# Altium schematic sheet Power.SchDoc(U_Power)
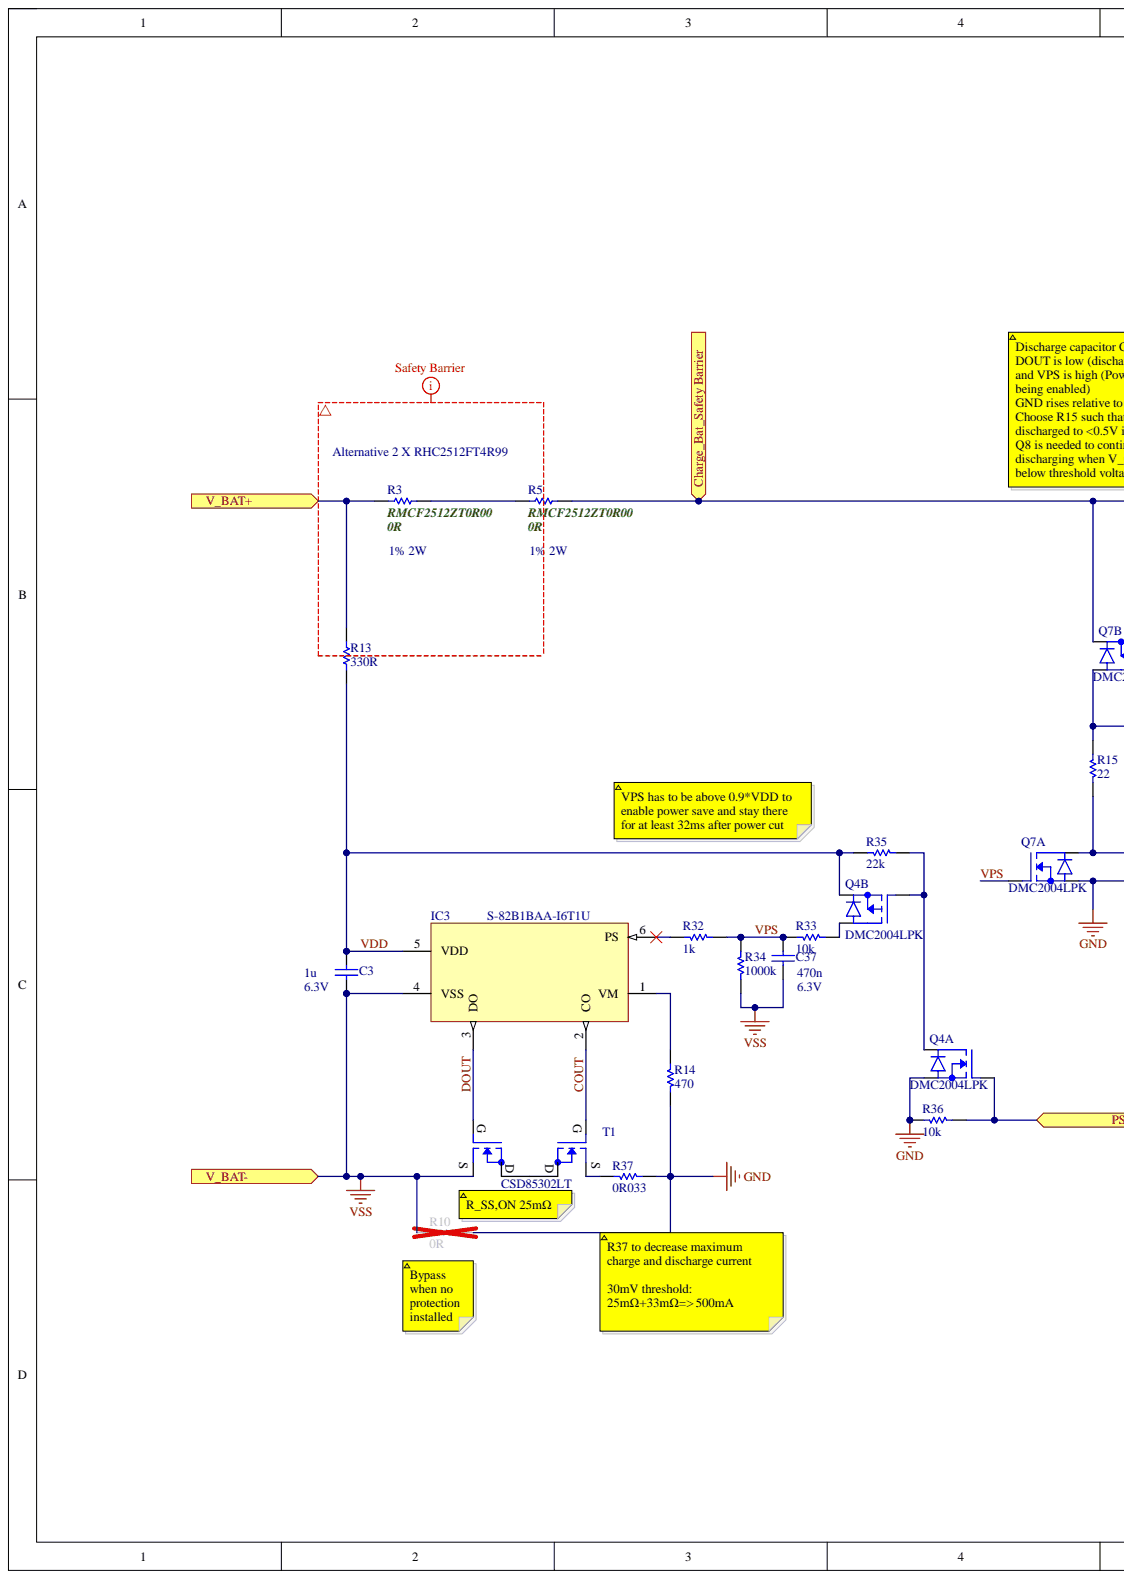
[diagram p0: Power.SchDoc, left]
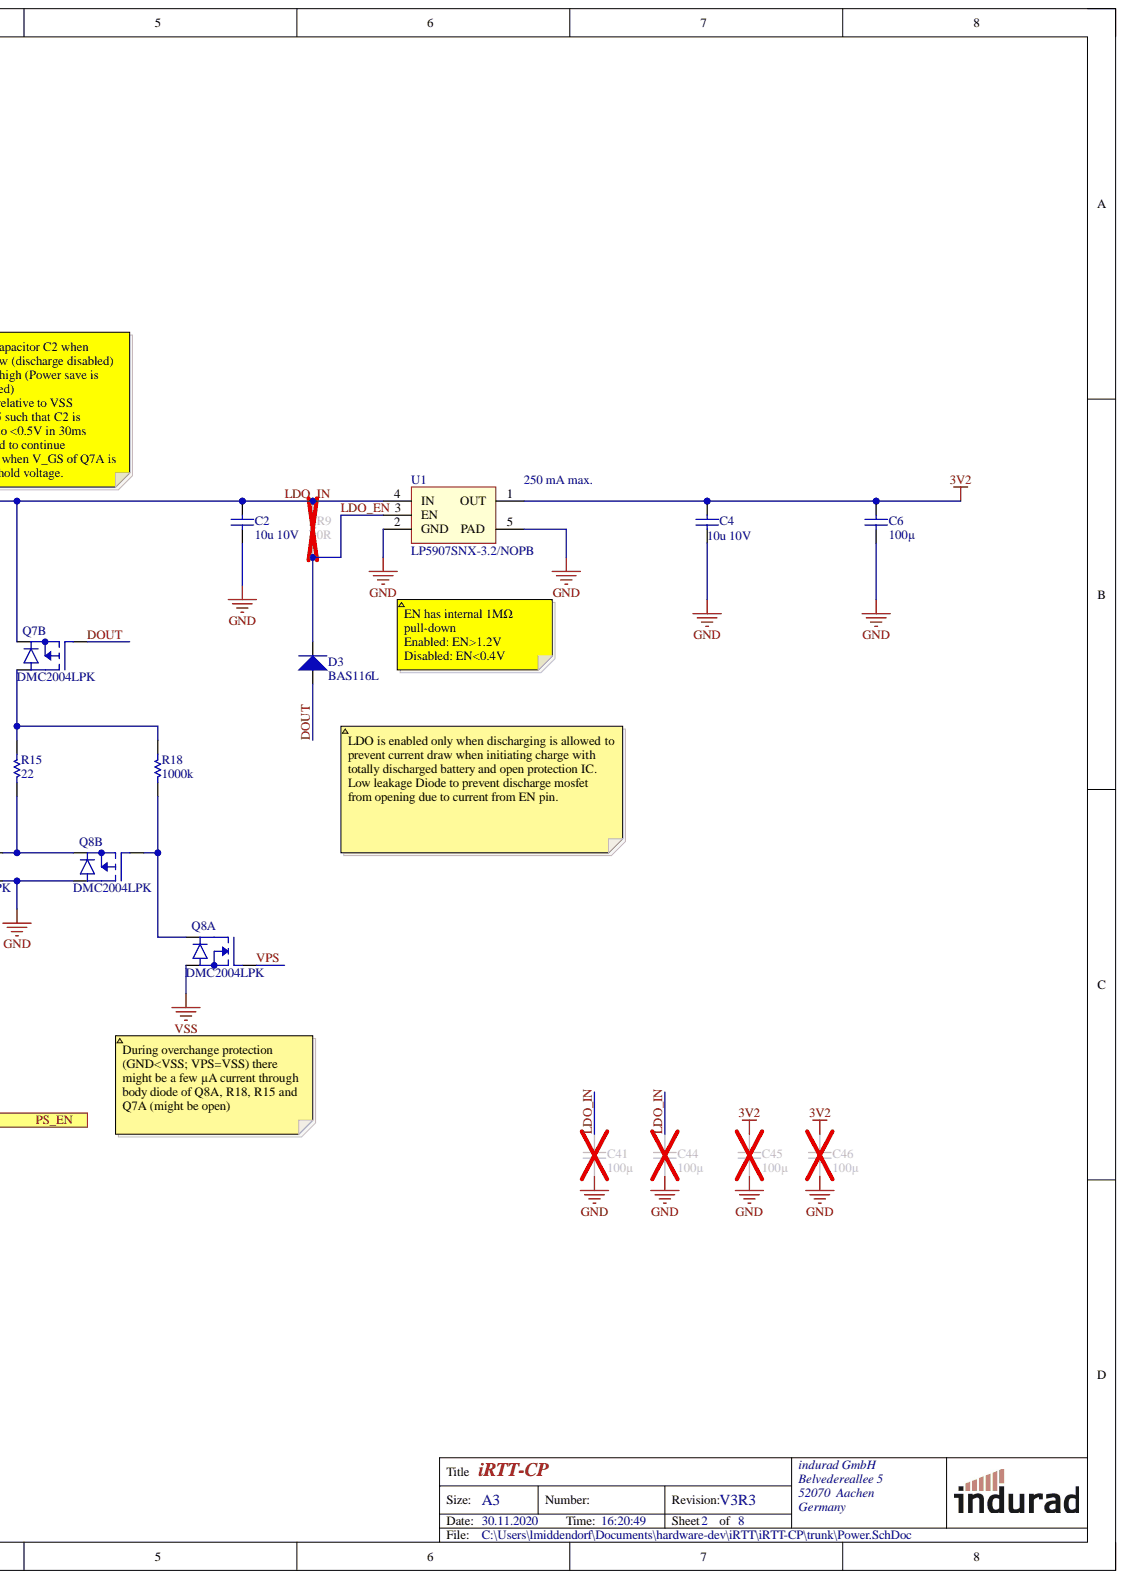
[diagram p1: Power.SchDoc, right]

## Parts
C2  10u 10V  [0805_N_(2012)]
C3  value 1u  Voltage: 6.3V  [0402_N_(1005)]  (hidden comment: 1u, 6.3V, X7R)
C4  10u 10V  value 10u  [0805_N_(2012)]
C6  100µ  [1206_N_(3216)]
C37  value 470n  Voltage: 6.3V  [0402_N_(1005)]  (hidden comment: 470n, 6.3V, X7R)
C41  100µ  [1210_N_(3225)]
C44  100µ  [1210_N_(3225)]
C45  100µ  [1210_N_(3225)]
C46  100µ  [1210_N_(3225)]
D3  BAS116L  [DFN1006-2]
IC3  S-82B1BAA-I6T1U  [SNT-6A]
Q4  DMC2004LPK  [X1-DFN1612-6]  (parts A,B)
Q7  DMC2004LPK  [X1-DFN1612-6]  (parts A,B)
Q8  DMC2004LPK  [X1-DFN1612-6]  (parts A,B)
R3  RMCF2512ZT0R00  value 0R  [CAPC_2512_RHC]
R5  RMCF2512ZT0R00  value 0R  [CAPC_2512_RHC]
R9  0R  [0201_N_(0603)]
R10  0R  [0402_N_(1005)]
R13  330R  [0603_N_(1608)]
R14  470  [0201_N_(0603)]
R15  22  [0603_N_(1608)]
R18  1000k  [0201_N_(0603)]
R32  1k  [0201_N_(0603)]
R33  10k  [0201_N_(0603)]
R34  1000k  [0201_N_(0603)]
R35  22k  [0201_N_(0603)]
R36  10k  [0201_N_(0603)]
R37  0R033  [0603_N_(1608)]
T1  Manufacturer Part Number: CSD85302LT  [4-XFLGA]  (hidden comment: N-MOSFET)
U1  LP5907SNX-3.2/NOPB  [4-XDFN]

## Ports
Charge_Bat_Safety Barrier, PS_EN, V_BAT+, V_BAT-

## Nets
3V2: C4.2 C6.2 C45.2 C46.2 U1.1(OUT)
GND: C2.1 C4.1 C6.1 C41.1 C44.1 C45.1 C46.1 Q4.1 Q7.1 Q8.3 R10.2 R14.1 R36.1 R37.1 U1.2(GND) U1.5(PAD)
VSS: C3.1 C37.1 IC3.4(VSS) Q8.1 R10.1 R34.2 T1.2
COUT: IC3.2(CO) T1.1
DOUT: D3.2 IC3.3(DO) Q7.5 T1.3
LDO_EN: D3.1 R9.2 U1.3(EN)
LDO_IN: C2.2 C41.2 C44.2 Q7.4 R5.2 R9.1 U1.4(IN) ; port Charge_Bat_Safety Barrier
PS_EN: Q4.2 R36.2 ; port PS_EN
V_BAT+: R3.1 R13.2 ; port V_BAT+
VDD: C3.2 IC3.5(VDD) Q4.4 R13.1 R35.2
VPS: C37.2 Q7.2 Q8.2 R32.1 R33.2 R34.1
NetIC3_1: IC3.1(VM) R14.2
NetIC3_6: IC3.6(PS) R32.2
NetQ4_3: Q4.3 R33.1
NetQ4_5: Q4.5 Q4.6 R35.1
NetQ7_3: Q7.3 R15.1 R18.1
NetQ7_6: Q7.6 Q8.4 R15.2
NetQ8_5: Q8.5 Q8.6 R18.2
NetR3_2: R3.2 R5.1
NetR37_2: R37.2 T1.4

## Library data of the parts
C2-C4 C37 C41 C44-C46 = Cap: Capacitor; Component Kind: Standard; Signal Integrity: Cap; Simulation: CAP; Library Name: Indurad.SchLib
  C2: Value: 10u 10V
  C3 C37: Dielectric: X7R
  C4: Supplier 1: Farnell; Supplier Part Number 1: 2118132
  C41 C44-C46: Value: 100µ
C6 = Cap: Capacitor; Manufacturer: Murata Electronics North America; Manufacturer Part Number: GRM31CR60J107ME39L; Capacitance: 100µF; Temperature Coefficient: X5R; Tolerance: ±20%; Voltage - Rated: 6.3V; Applications: General Purpose; Category: Capacitors; Component Kind: Standard; ComponentLink1Description: http://www.murata.com/~/media/webrenewal/support/library/catalog/products/capacitor/mlcc/c02e.ashx; ComponentLink1URL: http://www.murata.com/~/media/webrenewal/support/library/catalog/products/capacitor/mlcc/c02e.ashx; Features: -; Height - Seated (Max): -; Lead Spacing: -; Lead Style: -; Mounting Type: Surface Mount, MLCC; Operating Temperature: -55°C ~ 85°C; Package / Case: 1206 (3216 Metric); Packaging: Tape & Reel (TR); Pricing 1: 2000=0.30483, 4000=0.2845, 10000=0.27434, 14000=0.27028, 50000=0.2591, 100000=0.24894 (EUR); Ratings: -; RoHS: RoHS Compliant; Signal Integrity: Cap; Simulation: CAP; Size / Dimension: 0.126" L x 0.063" W (3.20mm x 1.60mm); Stock 1: 132000; Supplier 1: Digi-Key; Supplier Part Number 1: 490-4539-2-ND; Thickness (Max): 0.071" (1.80mm); Value: 100µ; Library Name: Indurad.SchLib
D3 = BAS116L: Low-leakage diode; Manufacturer: Diodes; Manufacturer Part Number: BAS116LPH4-7B; Average Rectified Current: 215mA; Element Configuration: Single; Forward Voltage: 1.25V; Max Power Dissipation: 300mW; Max Repetitive Reverse Voltage (Vrrm): 85V; Max Surge Current: 4A; Peak Reverse Current: 80nA; Power Rating: 200mW; Recovery Time: 3000ns; Reverse Recovery Time: 3us; Case/Package: DFN; Component Kind: Standard; ComponentLink8Description: Data Sheet; ComponentLink8URL: https://www.diodes.com/assets/Datasheets/BAS116LPH4.pdf; Contact Plating: Gold; Forward Current: 215mA; Height: 1.075mm; Lead Free: Lead Free; Length: 1.08mm; Library Name: D_Diode.SchLib; Max Operating Temperature: 150°C; Max Reverse Voltage (DC): 85V; Min Operating Temperature: -55°C; Mount: Surface Mount; Number of Pins: 2; Package Quantity: 10000; Packaging: Tape and Reel; Peak Non-Repetitive Surge Current: 4A; Power Dissipation: 300mW; REACH SVHC: No SVHC; RoHS: Yes; Supplier 1: Digi-Key; Supplier 2: Farnell; Supplier 3: Mouser; Supplier Part Number 1: BAS116LPH4-7BDICT-ND; Supplier Part Number 2: 3127201; Supplier Part Number 3: 621-BAS116LPH4-7B; Width: 0.675mm
IC3 = S-82B1BAA: BATTERY PROTECTION IC WITH POWER-SAVING FUNCTION FOR 1-CELL PACK; Manufacturer: ABLIC; Component Kind: Standard; ComponentLink1Description: Data Sheet; ComponentLink1URL: https://www.ablic.com/en/doc/datasheet/battery_protection/S82B1B_E.pdf; Library Name: IC_Integrated_Circuit.SchLib; Manufacturer Part Number: S-82B1BAA-I6T1U; Supplier 1: DigiKey; Supplier Part Number 1: 1662-S-82B1BAA-I6T1UCT-ND
Q4 Q7 Q8 = FET_DMC2004LPK: COMPLEMENTARY PAIR ENHANCEMENT MODE MOSFET; Manufacturer: Diodes; Manufacturer Part Number: DMC2004LPK-7; Continuous Drain Current (ID): 600mA; Drain to Source Breakdown Voltage: 20V; Drain to Source Resistance: 400mR; FET Type: N and P-Channel; Gate to Source Voltage (Vgs): 8V; Input Capacitance: 150pF; Max Power Dissipation: 500mW; Number of Channels: 2; Rds On Max: 550mR; Case/Package: DFN; Component Kind: Standard; ComponentLink1Description: Data Sheet; ComponentLink1URL: https://www.diodes.com/assets/Datasheets/ds30854.pdf; Drain to Source Voltage (Vdss): 20V; Height: 0.48mm; Length: 1.6mm; Library Name: Q_Transistor.SchLib; Max Operating Temperature: 150°C; Min Operating Temperature: -65°C; Mount: Surface Mount; Number of Elements: 2; Number of Pins: 6; Package Quantity: 3000; Packaging: Tape and Reel; Power Dissipation: 500mW; Pricing 1: 1=0,41000, 10=0,35100, 100=0,24340, 500=0,19000, 1000=0,15444 (USD); Pricing 2: 1=0,41000, 10=0,34200, 100=0,20800, 1000=0,16100, 3000=0,13800, 9000=0,13800 (USD); Radiation Hardening: No; REACH SVHC: No SVHC; RoHS: Yes; Stock 1: 5425; Stock 2: 2996; Supplier 1: Digi-Key; Supplier 2: Mouser; Supplier Part Number 1: DMC2004LPK-7DICT-ND; Supplier Part Number 2: 621-DMC2004LPK-7; Weight: 0.000744oz; Width: 1.2mm
R3 R5 = Res_RHC_7R5: Resistor; Manufacturer: Stackpole Electronics Inc.; Manufacturer Part Number: RHC2512FT7R50; Power (Watts): 2W; Temperature Coefficient: ±100ppm/°C; Tolerance: ±1%; Category: Resistors; Component Kind: Standard; ComponentLink1Description: https://www.seielect.com/Catalog/SEI-rhc.pdf; ComponentLink1URL: https://www.seielect.com/Catalog/SEI-rhc.pdf; Composition: Thick Film; Failure Rate: -; Features: Moisture Resistant; Height - Seated (Max): 0.028" (0.70mm); Operating Temperature: -55°C ~ 155°C; Package / Case: 2512 (6432 Metric); Packaging: Cut Tape (CT); Part Status: Active; Pricing 1: 1=0.81, 10=0.722, 100=0.5241, 1000=0.30981 (EUR); RoHS: RoHS Compliant; Signal Integrity: Res; Simulation: RESISTOR; Size / Dimension: 0.248" L x 0.126" W (6.30mm x 3.20mm); Stock 1: 0; Supplier 1: Digi-Key; Supplier Device Package: 2512; Supplier Part Number 1: RMCF2512ZT0R00CT-ND; Library Name: Indurad.SchLib
R9 R10 R13-R15 R18 R32-R37 = Res: Resistor; Component Kind: Standard; Signal Integrity: Res; Simulation: RESISTOR; Library Name: Indurad.SchLib
  R9 R10: Value: 0R
  R13: Value: 330R
  R14: Value: 470
  R15: Value: 22
  R18 R34: Value: 1000k
  R32: Value: 1k
  R33 R36: Value: 10k
  R35: Value: 22k
  R37: Value: 0R033
T1 = MOSFET-N-CH DUAL CSD85302L: CSD85302L 20 V Dual N-Channel NexFET™ Power MOSFET; Manufacturer: Texas Instruments; FET Feature: Standard; FET Type: 2 N-Channel (Dual) Common Drain; Gate Charge (Qg) (Max) @ Vgs: 7.8nC @ 4.5V; Power - Max: 1.7W; Category: Discrete Semiconductor Products; Component Kind: Standard; ComponentLink1Description: http://www.ti.com/lit/ds/symlink/csd85302l.pdf; ComponentLink1URL: http://www.ti.com/lit/ds/symlink/csd85302l.pdf; Current - Continuous Drain (Id) @ 25°C: -; Drain to Source Voltage (Vdss): -; Input Capacitance (Ciss) (Max) @ Vds: -; Mounting Type: Surface Mount; Operating Temperature: -55°C ~ 150°C (TJ); Package / Case: 4-XFLGA; Packaging: Cut Tape (CT); Part Status: Active; Pricing 1: 1=0.61, 10=0.531, 100=0.4097 (EUR); Rds On (Max) @ Id, Vgs: -; RoHS: RoHS Compliant; Stock 1: 0; Supplier 1: Digi-Key; Supplier Device Package: 4-Picostar (1.31x1.31); Supplier Part Number 1: 296-43314-1-ND; Vgs(th) (Max) @ Id: -; Library Name: Indurad.SchLib
U1 = LP5907SNX-3.2: Ultralow-Noise, High PSRR, Fast RF 200mA LDO Linear Regulators (Rev. K); Manufacturer: Texas Instruments; Control Features: Enable; Current - Output: 250mA; Output Configuration: Positive; Output Type: Fixed; Protection Features: Over Temperature, Short Circuit; PSRR: 90dB ~ 60dB (100Hz ~ 100kHz); Voltage - Input (Max): 5.5V; Voltage - Output (Min/Fixed): 3.2V; Voltage Dropout (Max): 0.25V @ 250mA; Category: Integrated Circuits (ICs); Component Kind: Standard; ComponentLink1Description: http://www.ti.com/lit/ds/symlink/lp5907.pdf; ComponentLink1URL: http://www.ti.com/lit/ds/symlink/lp5907.pdf; Manufacturer Part Number: LP5907SNX-3.2/NOPB; Mounting Type: Surface Mount; Operating Temperature: -40°C ~ 125°C; Package / Case: 4-XDFN Exposed Pad; Packaging: Cut Tape (CT); Part Status: Active; Pricing 1: 1=0.48, 10=0.408, 100=0.3042, 500=0.23898, 1000=0.18467 (EUR); RoHS: RoHS Compliant; Stock 1: 2319; Supplier 1: Digi-Key; Supplier Device Package: 4-X2SON (1x1); Supplier Part Number 1: 296-40374-1-ND; Voltage - Output (Max): -; Library Name: Indurad.SchLib
